# Altium schematic sheet ADC Chip.SchDoc
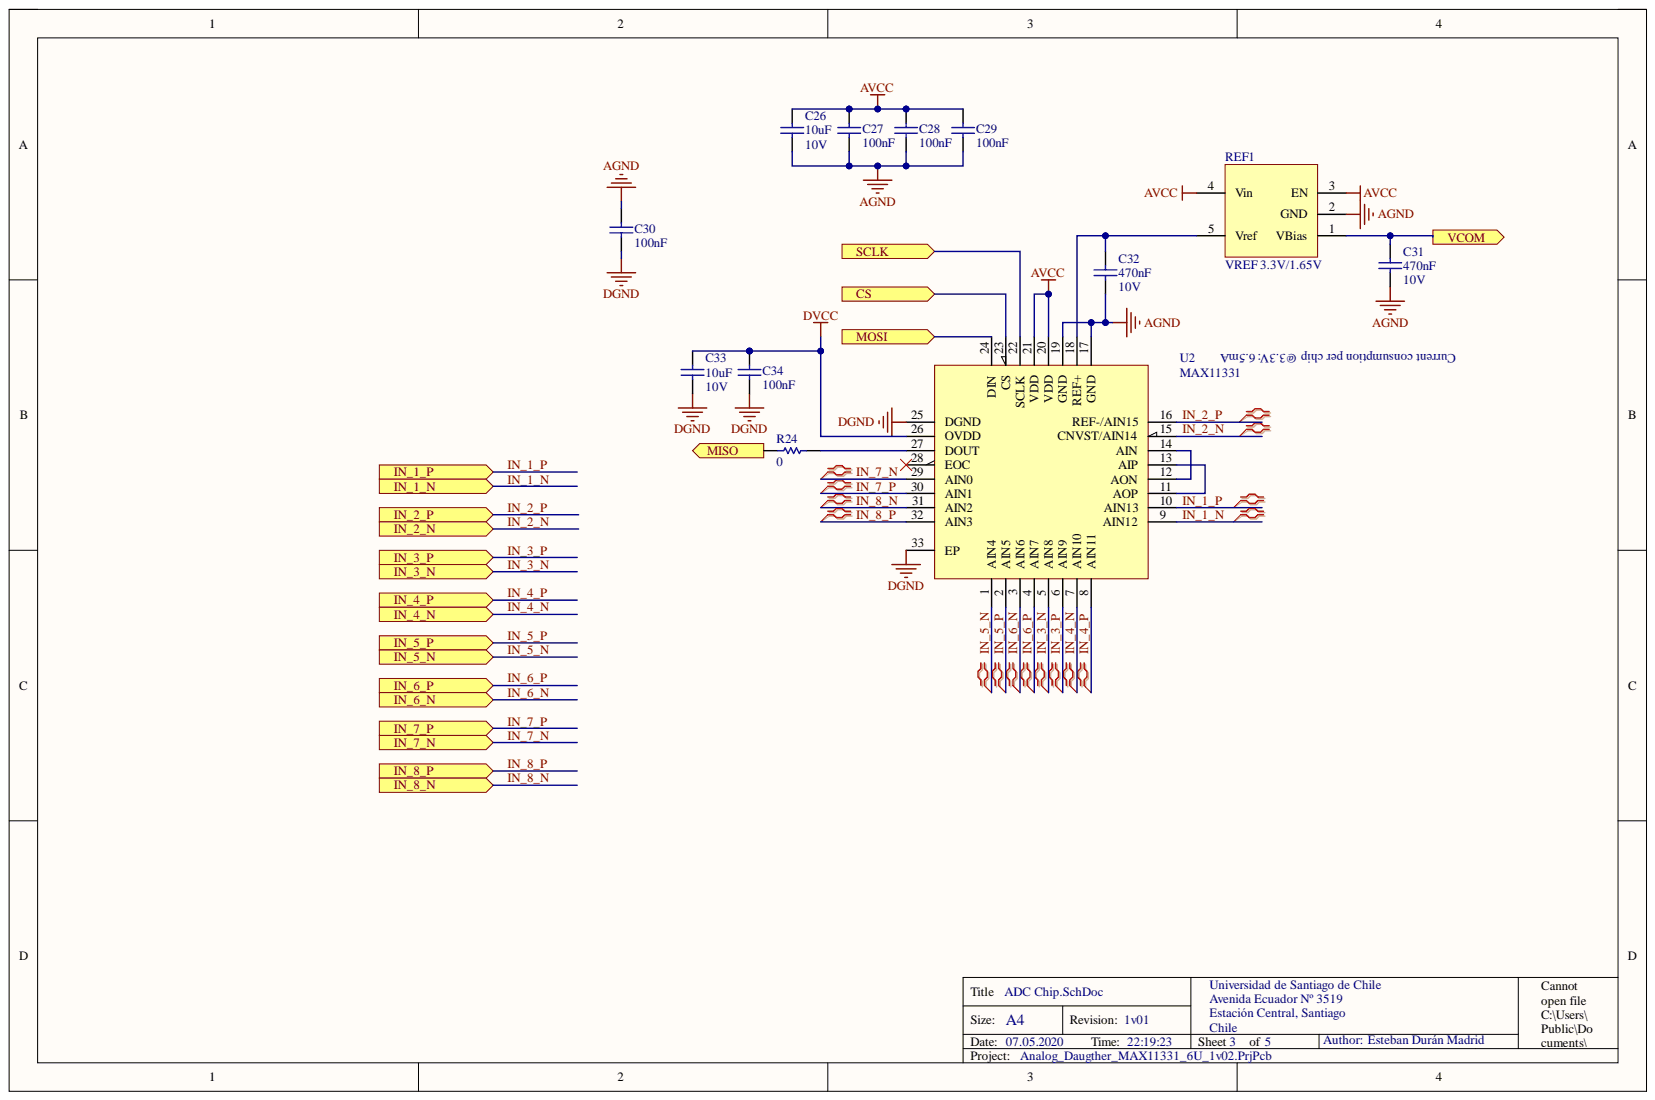
[diagram p0: ADC Chip.SchDoc]

## Parts
C26  10uF  Voltage: 10V  [CAP_0603]
C27  100nF  [CAP_0603]
C28  100nF  [CAP_0603]
C29  100nF  [CAP_0603]
C30  100nF  [CAP_0603]
C31  470nF  Voltage: 10V  [CAP_0603]
C32  470nF  Voltage: 10V  [CAP_0603]
C33  10uF  Voltage: 10V  [CAP_0603]
C34  100nF  [CAP_0603]
R24  0  [RES_0603]
REF1  VREF 3.3V/1.65V  [SOT-23-5]
U2  MAX11331  [32-TQFN-EP (5x5)]

## Ports
CS, IN_1_N, IN_1_P, IN_2_N, IN_2_P, IN_3_N, IN_3_P, IN_4_N, IN_4_P, IN_5_N, IN_5_P, IN_6_N, IN_6_P, IN_7_N, IN_7_P, IN_8_N, IN_8_P, MISO, MOSI, SCLK, VCOM

## Nets
AGND: C26.2 C27.2 C28.2 C29.2 C30.1 C31.2 C32.2 REF1.2(GND) U2.17(GND) U2.19(GND)
AVCC: C26.1 C27.1 C28.1 C29.1 REF1.3(EN) REF1.4(Vin) U2.20(VDD) U2.21(VDD)
DGND: C30.2 C33.2 C34.2 U2.25(DGND) U2.33(EP)
DVCC: C33.1 C34.1 U2.26(OVDD)
CS: U2.23(CS) ; port CS
IN_1_N: U2.9(AIN12) ; port IN_1_N
IN_1_P: U2.10(AIN13) ; port IN_1_P
IN_2_N: U2.15(CNVST/AIN14) ; port IN_2_N
IN_2_P: U2.16(REF-/AIN15) ; port IN_2_P
IN_3_N: U2.5(AIN8) ; port IN_3_N
IN_3_P: U2.6(AIN9) ; port IN_3_P
IN_4_N: U2.7(AIN10) ; port IN_4_N
IN_4_P: U2.8(AIN11) ; port IN_4_P
IN_5_N: U2.1(AIN4) ; port IN_5_N
IN_5_P: U2.2(AIN5) ; port IN_5_P
IN_6_N: U2.3(AIN6) ; port IN_6_N
IN_6_P: U2.4(AIN7) ; port IN_6_P
IN_7_N: U2.29(AIN0) ; port IN_7_N
IN_7_P: U2.30(AIN1) ; port IN_7_P
IN_8_N: U2.31(AIN2) ; port IN_8_N
IN_8_P: U2.32(AIN3) ; port IN_8_P
MISO: R24.1 ; port MISO
MOSI: U2.24(DIN) ; port MOSI
SCLK: U2.22(SCLK) ; port SCLK
VCOM: C31.1 REF1.1(VBias) ; port VCOM
NetC32_1: C32.1 REF1.5(Vref) U2.18(REF+)
NetR24_2: R24.2 U2.27(DOUT)
NetU2_11: U2.11(AOP) U2.13(AIP)
NetU2_12: U2.12(AON) U2.14(AIN)

Not connected: U2 28(EOC)

## Library data of the parts
C26 C33 = Cap_0603: Capacitor Ceramic; Manufacturer Part-Number: GRM188R61A106KE69J; Component Kind: Standard; Digikey Part-Number: 490-14372-1-ND; Signal Integrity: Cap; Simulation: CAP; Value: 10uF; Library Name: Componentes_SOC.SchLib
C27-C30 C34 = Cap_0603: Capacitor; Manufacturer Part-Number: LD03YC104KAB2A; Rule: PcbType=ProtelPcb; Component Kind: Standard; Digikey Part-Number: 478-4929-1-ND; LatestRevisionDate: 17-Jul-2002; LatestRevisionNote: Re-released for DXP Platform.; PackageReference: RAD-0.3; Published: 8-Jun-2000; Signal Integrity: Cap; Simulation: CAP; Value: 100nF; Library Name: Componentes_SOC.SchLib; Publisher: Altium Limited
C31 C32 = Cap_0603: Capacitor Ceramic; Manufacturer Part-Number: GRM188R61A474KA61D; Component Kind: Standard; Digikey Part-Number: 490-1539-1-ND; Signal Integrity: Cap; Simulation: CAP; Value: 470nF; Library Name: Componentes_SOC.SchLib
R24 = Res0603: Manufacturer Part-Number: CRCW06030000Z0EA; Component Kind: Standard; Digikey Part-Number: 541-0.0GCT-ND; LatestRevisionDate: 17-Jul-2002; LatestRevisionNote: Re-released for DXP Platform.; PackageDescription: Axial Device, Thru-Hole; 2 Leads; 0.3 in Pin Spacing; PackageReference: AXIAL-0.3; Published: 8-Jun-2000; Signal Integrity: Res; Simulation: RESISTOR; Value: 0; Library Name: Componentes_SOC.SchLib; Publisher: Altium Limited
REF1 = VREF 3.3V/1.65V: Manufacturer Part-Number: REF2033AIDDCT; Component Kind: Standard; Digikey Part-Number: 296-40487-1-ND; Library Name: Componentes_SOC.SchLib
U2 = MAX11331: ADC 12 bits SPI; Manufacturer Part-Number: MAX11331ATJ+; Component Kind: Standard; Digikey Part-Number: MAX11331ATJ+-ND; Library Name: Componentes_SOC.SchLib
